# Altium schematic sheet max_amplificador.SchDoc
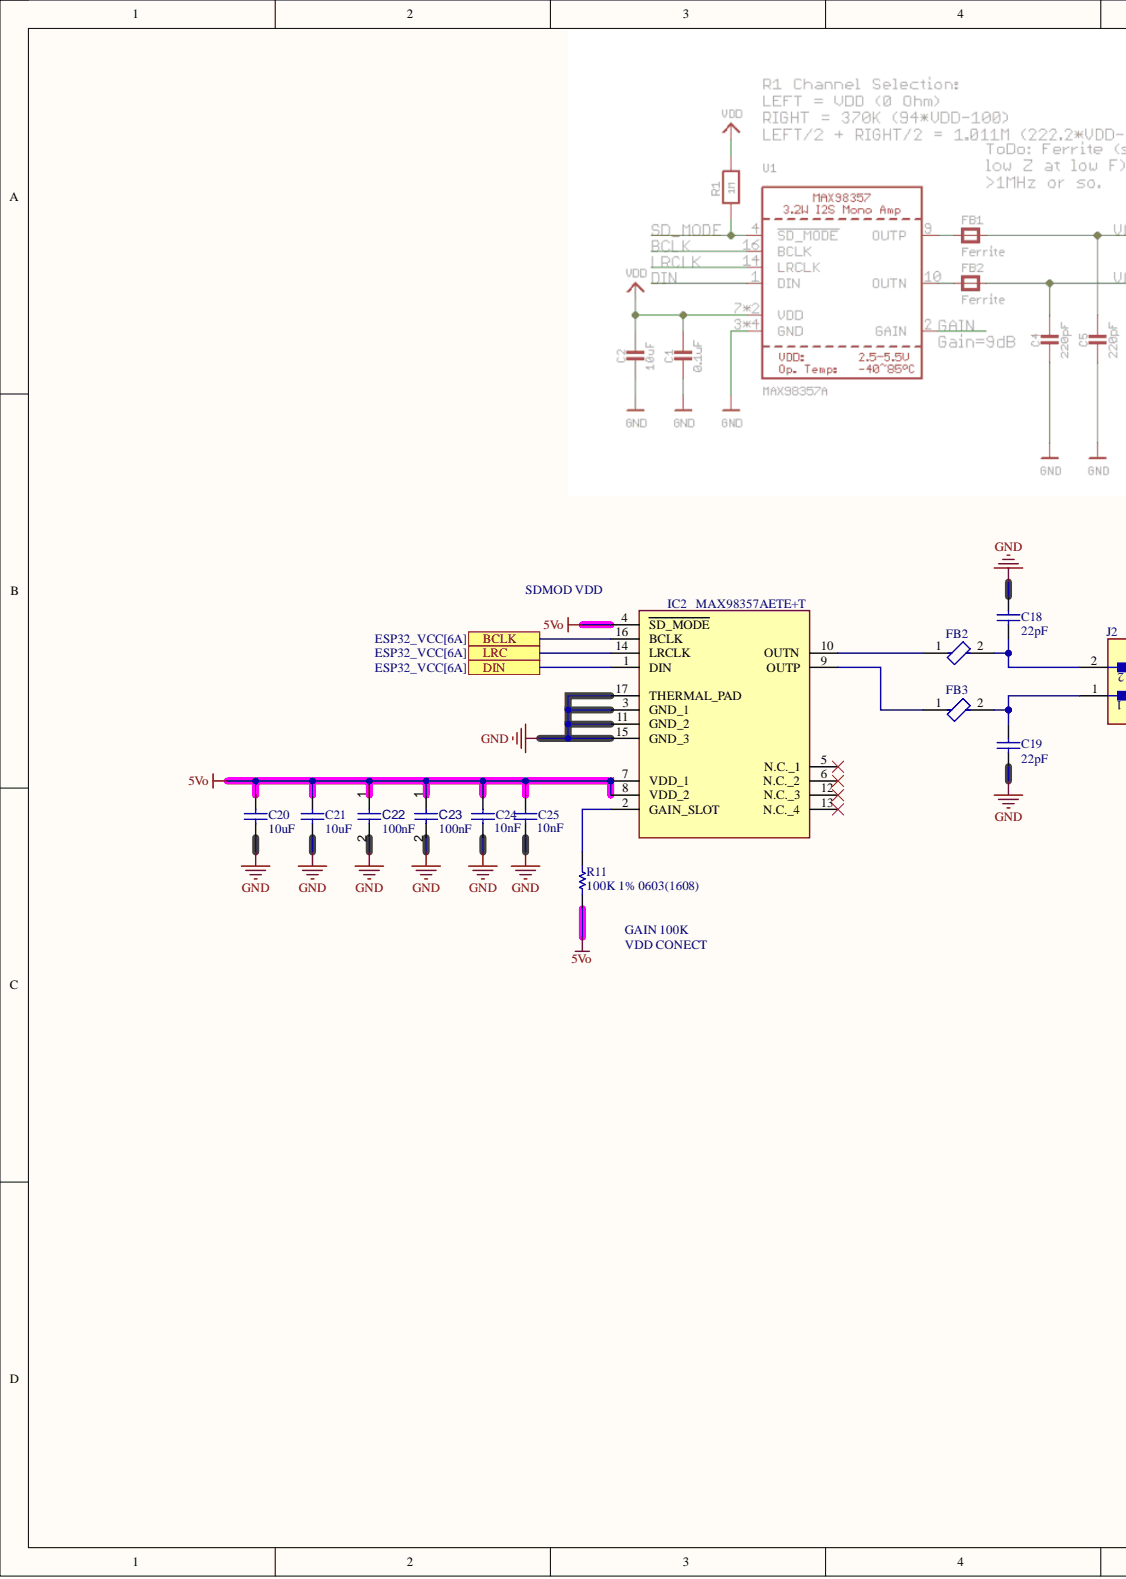
[diagram p0: max_amplificador.SchDoc, left]
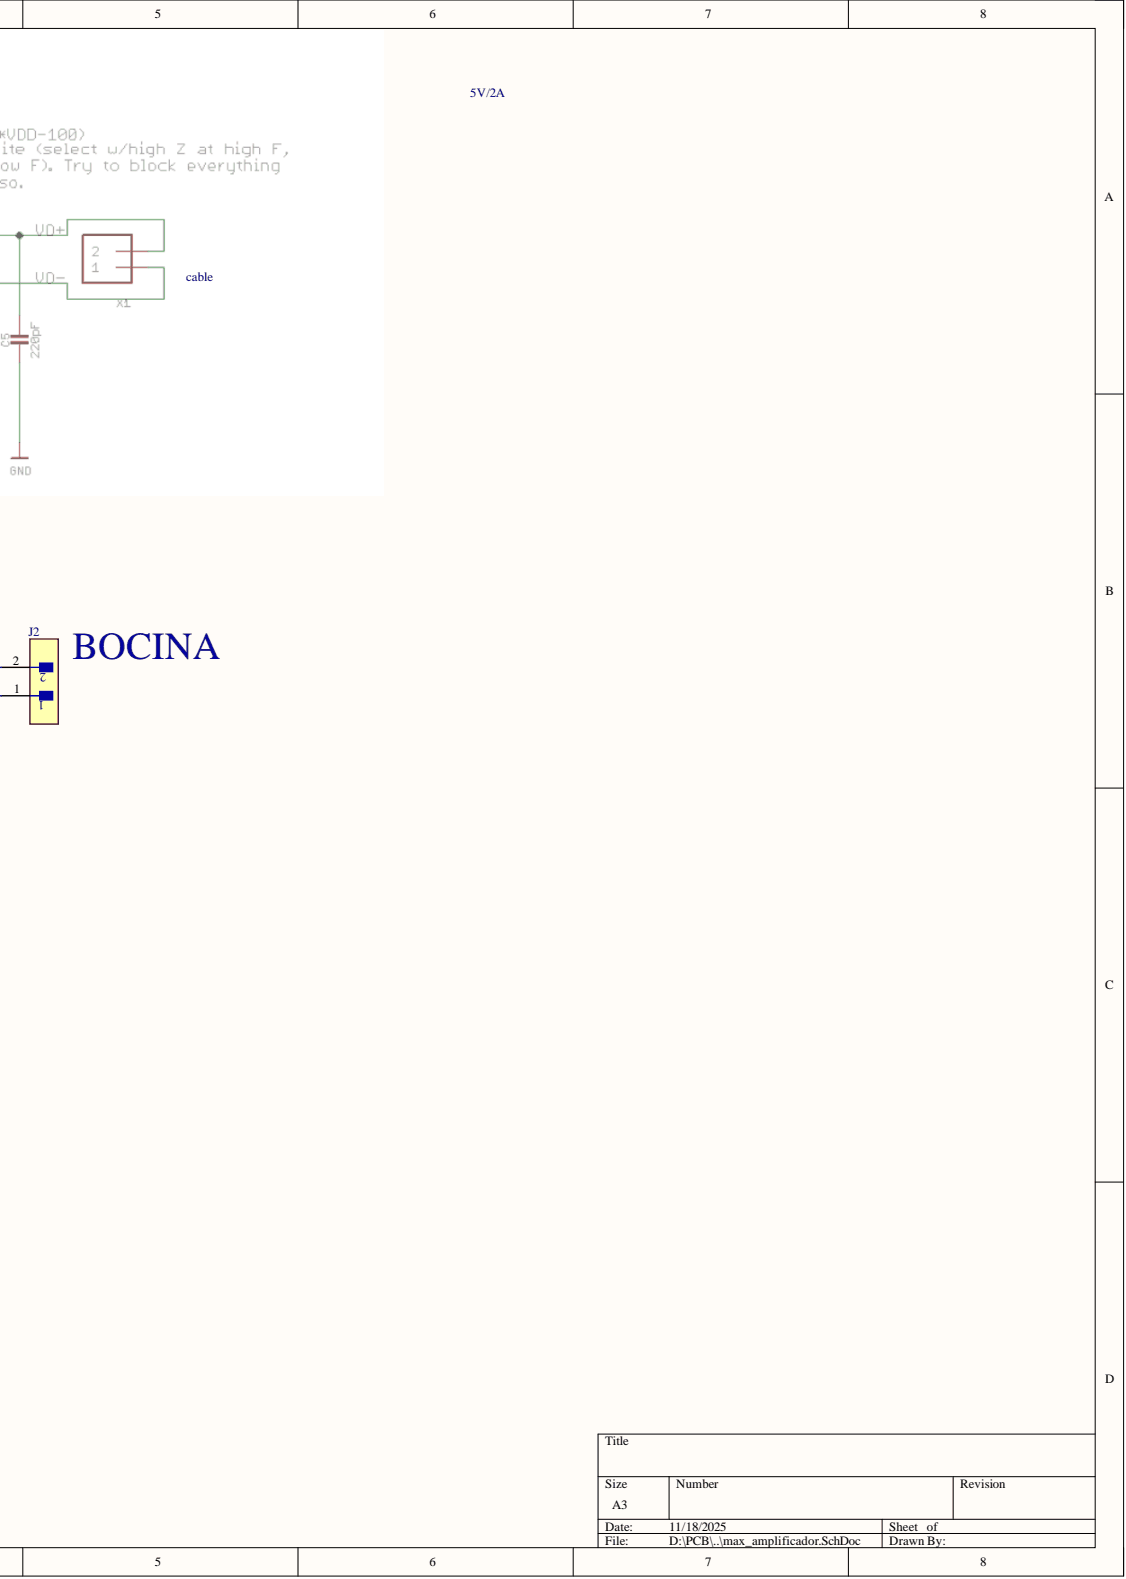
[diagram p1: max_amplificador.SchDoc, right]

## Parts
C18  value 22pF  [CAPC1608X90X35ML15T15]  (hidden comment: 06035A221J4T2A)
C19  value 22pF  [CAPC1608X90X35ML15T15]  (hidden comment: 06035A221J4T2A)
C20  value 10uF  [CAPC0603(1608)100_L]  (hidden comment: CAP 10uF 6.3V 0603(1608))
C21  value 10uF  [CAPC0603(1608)100_L]  (hidden comment: CAP 10uF 6.3V 0603(1608))
C22  value 100nF  [CAPC1005X56X25ML10T15]  (hidden comment: Capacitor 0.1 uF +/- 5% 16 V 0402)
C23  value 100nF  [CAPC1005X56X25ML10T15]  (hidden comment: Capacitor 0.1 uF +/- 5% 16 V 0402)
C24  value 10nF  [CAPC0603X33X15NL03T05]  (hidden comment: 0201ZC103JAT2A)
C25  value 10nF  [CAPC0603X33X15NL03T05]  (hidden comment: 0201ZC103JAT2A)
FB2  BLM21PG220SN1D  [FP-BLM21-0_2-t0_85-e0_5-MFG]  (value not drawn)
FB3  BLM21PG220SN1D  [FP-BLM21-0_2-t0_85-e0_5-MFG]  (value not drawn)
IC2  MAX98357AETE+T  [QFN50P300X300X80-17N]
J2  B2B-XH-A(LF)(SN)  [FP-B2B-XH-A_LF_SN-MFG]  (value not drawn)
R11  100K 1% 0603(1608)  [RESC0603(1608)_N]

## Ports
BCLK, DIN, LRC

## Nets
5Vo: C20.2 C21.2 C22.1 C23.1 C24.2 C25.2 IC2.4(SD_MODE) IC2.7(VDD_1) IC2.8(VDD_2) R11.1
GND: C18.2 C19.2 C20.1 C21.1 C22.2 C23.2 C24.1 C25.1 IC2.3(GND_1) IC2.11(GND_2) IC2.15(GND_3) IC2.17(THERMAL_PAD)
BCLK: IC2.16(BCLK) ; port BCLK
DIN: IC2.1(DIN) ; port DIN
LRC: IC2.14(LRCLK) ; port LRC
NetC18_1: C18.1 FB2.2 J2.2
NetC19_1: C19.1 FB3.2 J2.1
NetFB2_1: FB2.1 IC2.10(OUTN)
NetFB3_1: FB3.1 IC2.9(OUTP)
NetIC2_2: IC2.2(GAIN_SLOT) R11.2

Not connected: IC2 5(N.C._1) 6(N.C._2) 12(N.C._3) 13(N.C._4)

## Library data of the parts
C18 C19 = CMP-2006-04590-1: Manufacturer: AVX Interconnect / Elco; Component Kind: Standard; Library Name: Altium Content Vault; Part Number: 06035A221J4T2A
C20 C21 = CMP-1035-02796-1: CAP 10uF 6.3V ±10% 0603 (1608 Metric) Thickness 1mm SMD; Case-EIA: 0603; Case-Metric: 1608; Tolerance: Â±10%; Component Kind: Standard; Library Name: Altium Content Vault; Max Thickness: 1 mm; Rated Voltage: 6.3 V; Technology: SMT
C22 C23 = CMP-001-00001-6: Chip Capacitor, 0.1 uF, +/- 5%, 16 V, 0402 (1005 Metric); Capacitor Type: Ceramic; Voltage Rating: 16V; Case/Package: 0402; Component Kind: Standard; Max Operating Temperature: 125°C; Min Operating Temperature: -55°C; Mounting Technology: SM; Pins: 2; RoHS Compliant: True; Tolerance: 5%; Library Name: Carlos Christopher Carbajal Jordán
C24 C25 = CMP-2010-00529-1: Manufacturer: AVX Interconnect / Elco; Component Kind: Standard; Library Name: Altium Content Vault; Part Number: 0201ZC103JAT2A
FB2 FB3 = CMP-0686-00622-4: Chip Ferrite Bead, 0805, 22Ω @ 100MHz, 0.009Ω, 25%, 6A; Manufacturer Series: BLM21P; DC Resistance (DCR): 9mR; Impedance: 22R; Material: Steel; Number of Circuits: 1; Resistance: 10mR; Shielding: Unshielded; Case Code (Imperial): 0805; Case Code (Metric): 2012; Case/Package: 0805; Component Kind: Standard; Core Material: Ferrite; Current Rating: 6A; DC Current: 6A; DC Resistance-Max: 9mR; Depth: 1.25mm; Height: 0.85mm; Lead Free: Lead Free; Length: 2mm; Library Name: Altium Content Vault; Max Current Rating: 6A; Max DC Current: 6A; Max Operating Temperature: 125°C; Min Operating Temperature: -55°C; Number of Functions: 1; Number of Lines: 1; Number of Pins: 2; Package Quantity: 1; Packaging: Tape and Reel; Radiation Hardening: No; REACH SVHC: No SVHC; RoHS Compliant: Yes; Series: BLM21P; Terminal Finish: Tin; Termination: SMD/SMT; Test Frequency: 100MHz; Tolerance: 25%; Weight: 0.000194oz; Width: 1.25mm
IC2 = MAX98357AETE+T: Integrated Circuit; Manufacturer_Name: Analog Devices; Arrow Part Number: MAX98357AETE+T; Arrow Price/Stock: https://www.arrow.com/en/products/max98357aetet/analog-devices?utm_currency=USD&region=nac; Component Kind: Standard; Datasheet Link: https://datasheets.maximintegrated.com/en/ds/MAX98357A-MAX98357B.pdf; Height: 0.8mm; Library Name: MAX98357AETE+T.SchLib; Manufacturer_Part_Number: MAX98357AETE+T; Mouser Part Number: 700-MAX98357AETE+T; Mouser Price/Stock: https://www.mouser.co.uk/ProductDetail/Analog-Devices-Maxim-Integrated/MAX98357AETE%2bT?qs=AAveGqk956HhNpoJjF5x2g%3D%3D
J2 = CMP-2000-05888-3: CONN HEADER VERT 2POS 2.5MM; Connector Type: Male Pin; Contact Resistance: 20mR; Current Rating: 3A; Insulation Resistance: 1GR; Max Voltage Rating (AC): 250V; Number of Contacts: 2; Wire/Cable Gauge: 22AWG; Color: Natural; Component Kind: Standard; Contact Material: Brass; Contact Plating: Tin; Contact Type: Male Pin; Depth: 5.75mm; Fastening Type: Detent; Housing Color: White; Housing Material: Polyamide; Lead Free: Lead Free; Lead Pitch: 2.5mm; Length: 7.4mm; Library Name: Altium Content Vault; Max Current Rating: 3A; Max Operating Temperature: 85°C; Max Voltage Rating (DC): 250V; Min Operating Temperature: -25°C; Mount: Through Hole; Number of Positions: 2; Number of Rows: 1; Orientation: Straight; Packaging: Bulk; Pitch: 2.5mm; REACH SVHC: No SVHC; Series: XH; Termination: Solder; Voltage Rating: 250V; Voltage Rating (AC): 250V
R11 = CMP-1012-00736-1: 100K 0.1W 1% 0603 (1608 Metric)  SMD; Case-EIA: 0603; Case-Metric: 1608; Value: 100000.00 Ohm; Component Kind: Standard; Library Name: Altium Content Vault; Power: 0.1 W; Technology: SMT; Tolerance: 1 %
